# Altium schematic sheet schematic_flashlight.SchDoc(schematic_flashlight)
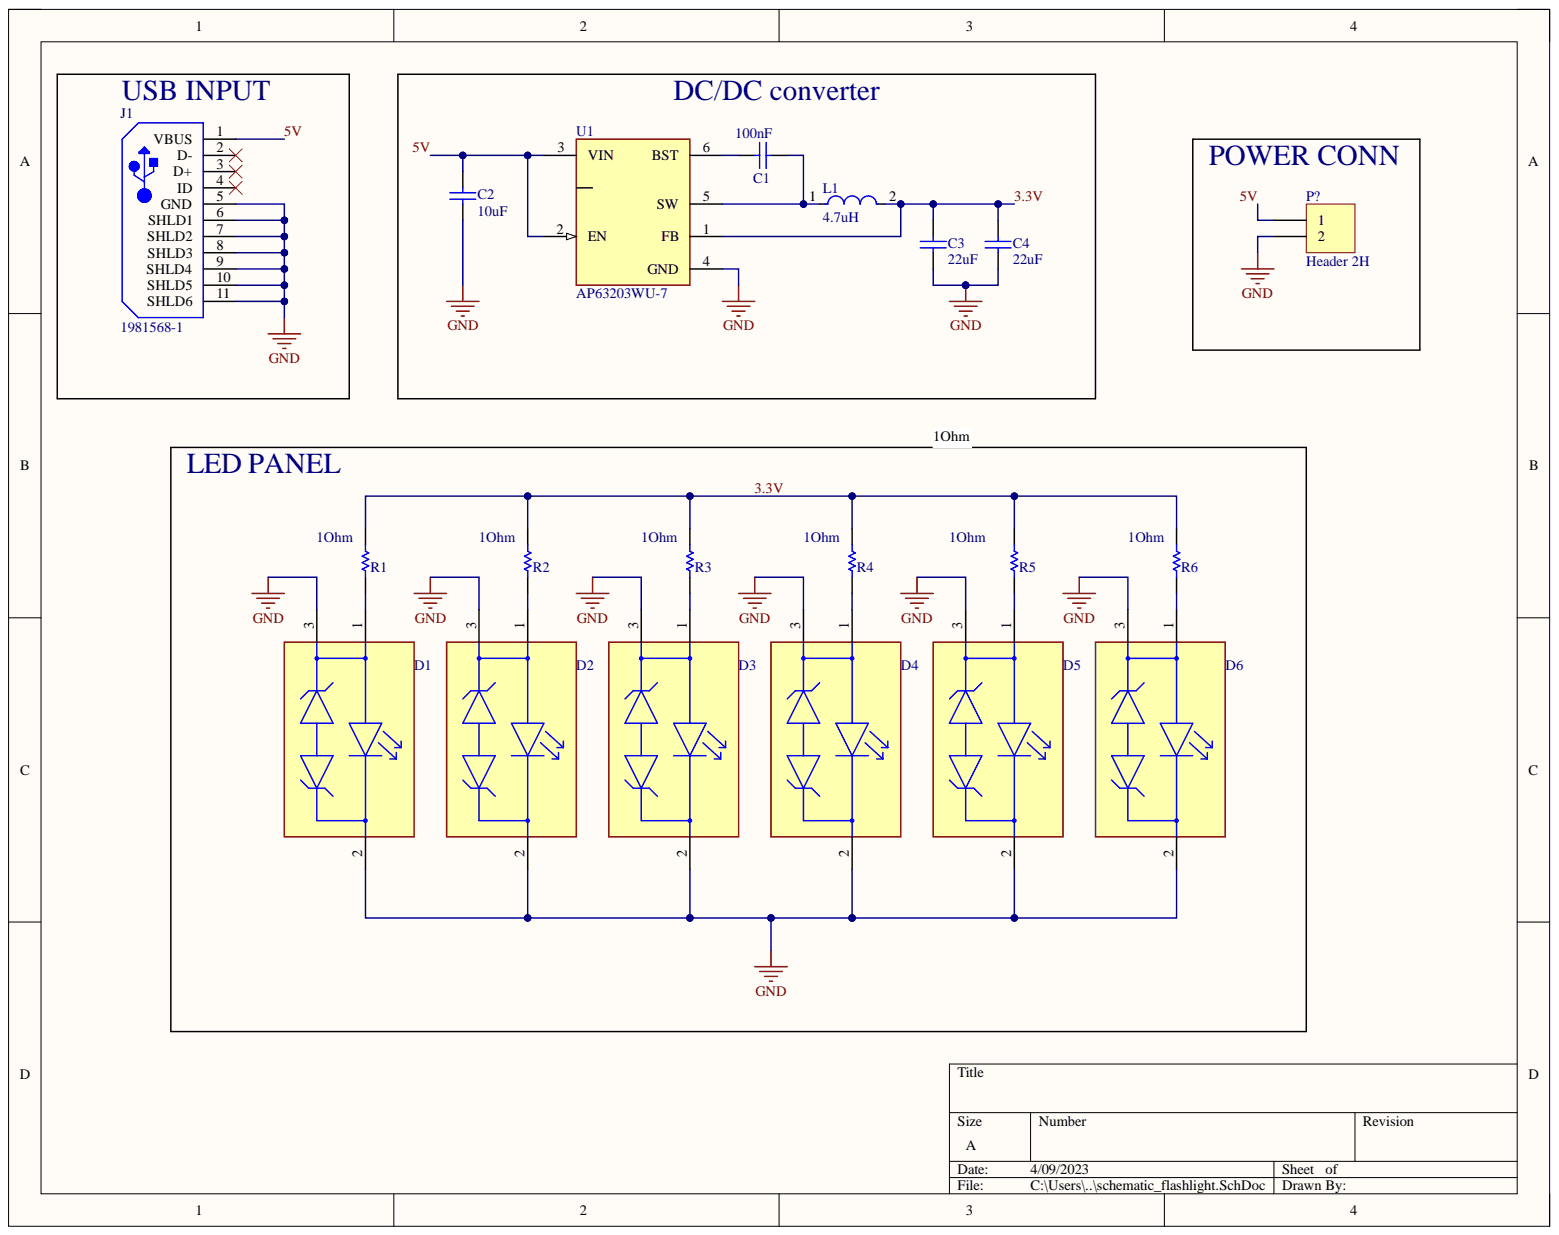
[diagram p0: schematic_flashlight.SchDoc]

## Parts
C1  100nF  [CAPC2013X145X50LL20T25]
C2  10uF  [CAPC2013X145X50LL20T25]
C3  22uF  [CAPC2013X145X50LL20T25]
C4  22uF  [CAPC2013X145X50LL20T25]
D1  SML-LXL8047USBCTR3  [FP-SML-LXL8047USBCTR3-MFG]  (value not drawn)
D2  SML-LXL8047USBCTR3  [FP-SML-LXL8047USBCTR3-MFG]  (value not drawn)
D3  SML-LXL8047USBCTR3  [FP-SML-LXL8047USBCTR3-MFG]  (value not drawn)
D4  SML-LXL8047USBCTR3  [FP-SML-LXL8047USBCTR3-MFG]  (value not drawn)
D5  SML-LXL8047USBCTR3  [FP-SML-LXL8047USBCTR3-MFG]  (value not drawn)
D6  SML-LXL8047USBCTR3  [FP-SML-LXL8047USBCTR3-MFG]  (value not drawn)
J1  1981568-1  [TECO-1981568-5_V]
L1  4.7uH  [FP-NR6028-MFG]
P?  Header 2H  [HDR1X2H]
R1  1Ohm  [RESC3216X60X45LL10T20]
R2  1Ohm  [RESC3216X60X45LL10T20]
R3  1Ohm  [RESC3216X60X45LL10T20]
R4  1Ohm  [RESC3216X60X45LL10T20]
R5  1Ohm  [RESC3216X60X45LL10T20]
R6  1Ohm  [RESC3216X60X45LL10T20]
U1  AP63203WU-7  [FP-TSOT26-MFG]

## Nets
GND: C2.1 C3.1 C4.1 D1.2 D1.3 D2.2 D2.3 D3.2 D3.3 D4.2 D4.3 D5.2 D5.3 D6.2 D6.3 J1.5(GND) J1.6(SHLD1) J1.7(SHLD2) J1.8(SHLD3) J1.9(SHLD4) J1.10(SHLD5) J1.11(SHLD6) P?.2 U1.4(GND)
3.3V: C3.2 C4.2 L1.2 R1.2 R2.2 R3.2 R4.2 R5.2 R6.2 U1.1(FB)
5V: C2.2 J1.1(VBUS) P?.1 U1.2(EN) U1.3(VIN)
NetC1_1: C1.1 L1.1 U1.5(SW)
NetC1_2: C1.2 U1.6(BST)
NetD1_1: D1.1 R1.1
NetD2_1: D2.1 R2.1
NetD3_1: D3.1 R3.1
NetD4_1: D4.1 R4.1
NetD5_1: D5.1 R5.1
NetD6_1: D6.1 R6.1

Not connected: J1 2(D-) 3(D+) 4(ID)

## Library data of the parts
C1-C4 = CMP-1036-04746-2: Manufacturer: Kemet; Part Number: C0805C105K4PACTU; Component Kind: Standard; Library Name: Altium Content Vault
D1-D6 = CMP-57192-000008-1: LED ROUND 3W BLUE 448NM SMD; Approvals: UL; Current Rating: 1.5A; Dominant Wavelength: 454nm; Forward Current: 700mA; Forward Voltage: 4.6V; Lens Style: Dome; Luminous Flux: 20lm; Luminous Intensity: 20mcd; Number of LEDs: 1; Test Temperature: 25°C; Viewing Angle: 100°; Case/Package: SMD/SMT; Color: Blue; Component Kind: Standard; Illumination Color: Blue; Lens Color: Blue; Library Name: Altium Content Vault; Max Current Rating: 700mA; Max Operating Temperature: 100°C; Max Power Dissipation: 3W; Min Operating Temperature: -40°C; Mount: Surface Mount; Number of Pins: 2; Operating Supply Voltage: 4.6V; Package Quantity: 1; Packaging: Tape and Reel; Power Rating: 3W; REACH SVHC: No SVHC; RoHS Compliant: Yes; Series: TitanBrite™; Test Current: 700mA; Wavelength: 454nm
J1 = CMP-2000-05408-1: Micro USB Receptacle Standard Type B Assy, 5-Pin SMD, RoHS, Tape and Reel; Manufacturer: TE Connectivity; Body Orientation: RightAngle; Current Rating (Max): 1/ContactA; Gender: RCP; Number of Contacts: 5POS; Number of Ports: 1Port; Pitch (mm): 0.65mm; Product Depth (mm): 5.6mm; Product Height (mm): 3mm; Product Length (mm): 8mm; Voltage Rating Max: 30VAC; Component Kind: Standard; Contact Material: CopperAlloy; Contact Plating: GoldOverNickel; Datasheet URL: http://www.mouser.com/ds/2/418/NG_CD_1981568_E2-621296.pdf; Datasheet Version: Rev. E2, 08/2015; Housing Material: Thermoplastic; Library Name: Altium Content Vault; Manufacturer URL: http://www.te.com/; Mounting Style: SurfaceMount; Number of Terminals: 5; Operating Temp Range: -55Cto85C; Operating Temperature Max Deg. C: 85C; Operating Temperature Min Deg. C: -55C; Packaging: TapeandReel; Termination Method: Solder; Type: MicroUSB
L1 = CMP-14484-000406-1: SMD Power Inductors 10uH ±20% 1.9A 84.5mΩ; Core Material: Ferrite; DC Resistance (DCR): 84.5mR; Self Resonant Frequency: 20MHz; Shielding: Shielded; Test Frequency: 100kHz; Case/Package: 2424; Component Kind: Standard; Current Rating: 1.9A; Halogen Free: Halogen Free; Height: 2.8mm; Height - Seated (Max): 0.11inch; Inductance: 10uH; Inductance Tolerance: 20%; Lead Free: Lead Free; Length: 0.236inch; Library Name: Altium Content Vault; Max DC Current: 1.9A; Max Operating Temperature: 120°C; Min Operating Temperature: -25°C; Mount: Surface Mount; Number of Pins: 2; Package Quantity: 2000; Packaging: Tape and Reel; Resistor Type: Wirewound; RMS Current (Irms): 1.9A; RoHS Compliant: Yes; Saturation Current: 1.9A; Series: NR; Termination: SMD/SMT; Tolerance: 20%; Width: 6mm
P? = Header 2H: Header, 2-Pin, Right Angle; Component Kind: Standard; LatestRevisionDate: 17-Jul-2002; LatestRevisionNote: Re-released for DXP Platform.; Library Name: Miscellaneous Connectors.IntLib; Signal Integrity: Connector; Publisher: Altium Limited
R1-R6 = CMP-2003-01921-1: Manufacturer: Vishay Dale; Part Number: CRCW12061R00FNEA; Component Kind: Standard; Library Name: Altium Content Vault
U1 = CMP-12757-000006-1: Buck Switching Regulator IC Positive Fixed 3.3V 1 Output 2A SOT-23-6 Thin, TSOT-23-6; Manufacturer: Diodes Inc.; Max Junction Temp: +160°C; Max Supply Voltage: 32V; Min Supply Voltage: 3.8V; Nominal Supply Current: 22uA; Number Of Outputs: 1; Standoff Height: 0.01mm; Switching Frequency: 1.1MHz; Ambient Temperature Range High: +85°C; Ambient Temperature Range Low: -40°C; Automotive: No; Category: IC; Component Kind: Standard; ComponentLink2Description: Datasheet; ComponentLink2URL: https://www.diodes.com/assets/Datasheets/AP63200-AP63201-AP63203-AP63205.pdf; Device Class L1: Integrated Circuits (ICs); Device Class L2: Power Management ICs; Device Class L3: Voltage Regulators - Switching; Digikey Description: IC REG BUCK 3.3V 2A TSOT26; Footprint Url: https://www.diodes.com/assets/Package-Files/TSOT26.pdf; Height: 1mm; Lead Free: Yes; Library Name: Altium Content Vault; Manufacturer Part Number: AP63203WU-7; Mouser Description: AP63203 Series 3.3 V 2 A SMT Fixed Low IQ Synchronous Buck - TSOT-26; Octopart: https://octopart.com/ap63203wu-7-diodes+inc.-98099550; Output Current: 2A; Output Type: Fixed; Output Voltage: 3.3V; Package: TSOT26; RoHS: TRUE; Switching Topology: Buck; Temperature: to +160°C; Temperature Range High: +160°C
